# Altium schematic sheet Power.SchDoc(Power)
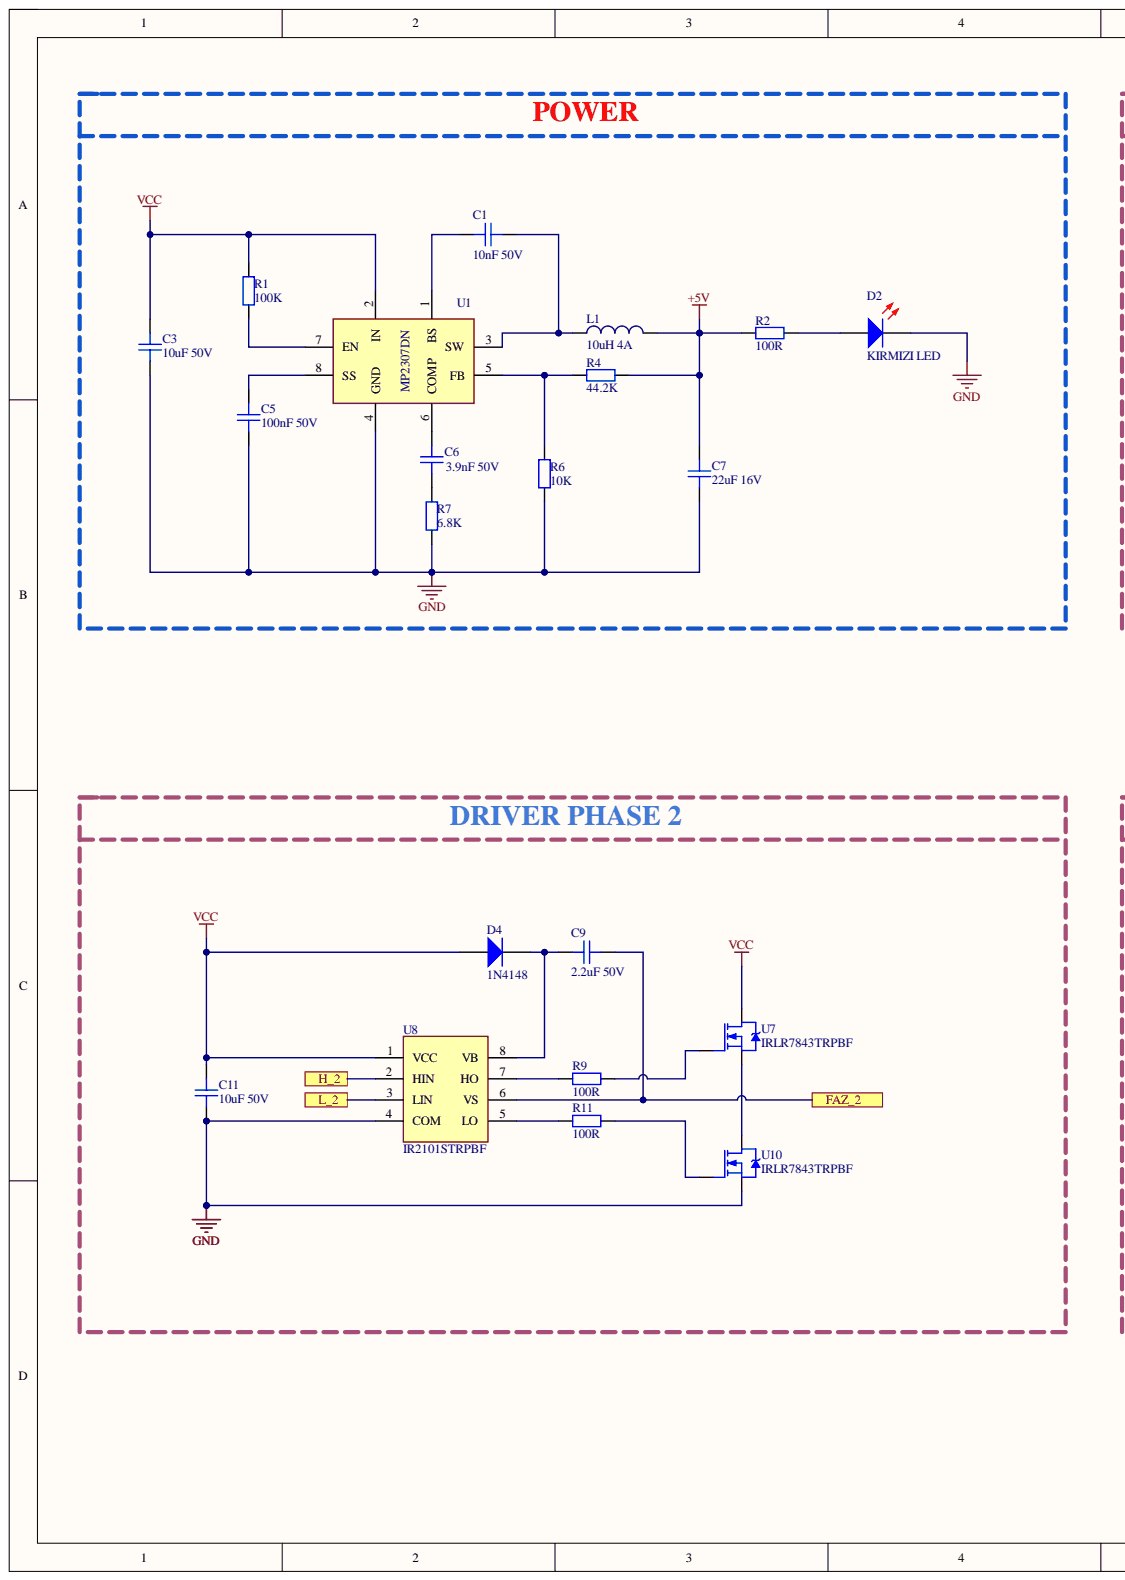
[diagram p0: Power.SchDoc, left]
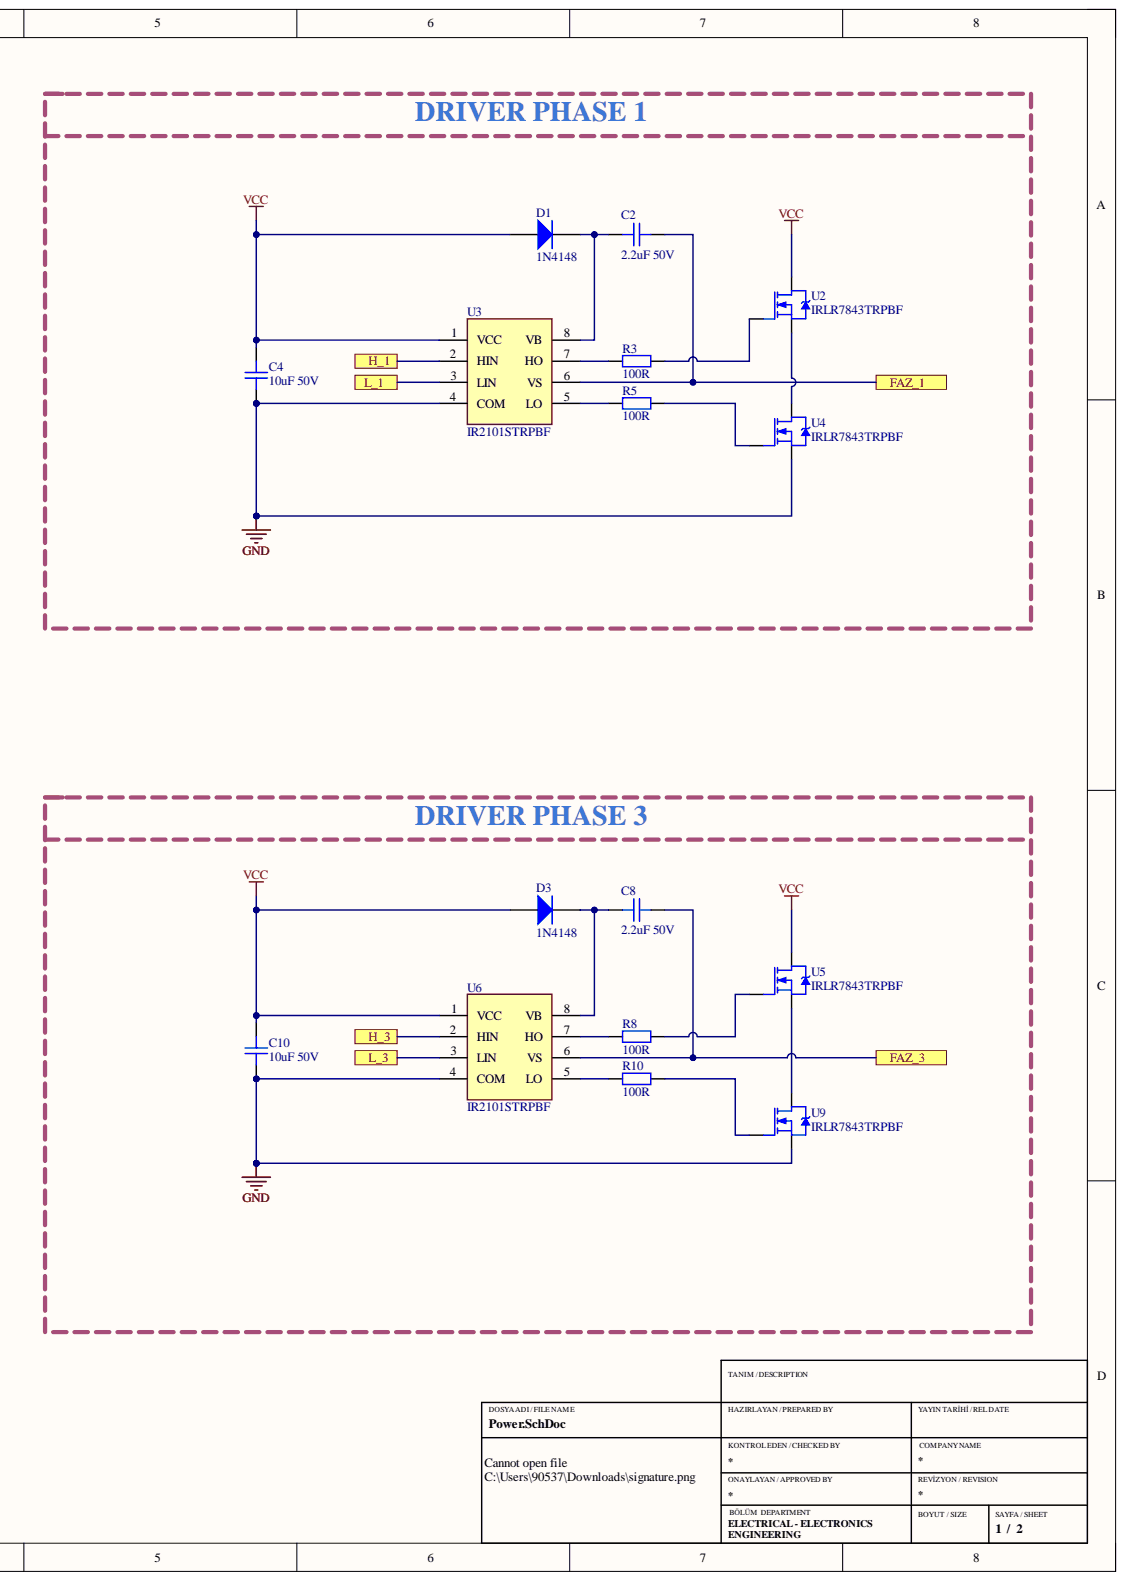
[diagram p1: Power.SchDoc, right]

## Parts
C1  Deger: 10nF 50V  [CAP 0805/2012]  (hidden comment: CAP CER 10000PF 50V X7R 0805)
C2  Deger: 2.2uF 50V  [CAP 1206/3216]  (hidden comment: CAP CER 2.2UF 50V X5R 1206)
C3  Deger: 10uF 50V  [CAP 1206/3216]  (hidden comment: CAP CER 10UF 50V X5R 1206)
C4  Deger: 10uF 50V  [CAP 1206/3216]  (hidden comment: CAP CER 10UF 50V X5R 1206)
C5  CAP CER 0.1UF 50V X7R 0805  [CAP 0805/2012]  (value not drawn)
C6  CAP CER 3900PF 50V X7R 0805  [CAP 0805/2012]  (value not drawn)
C7  Deger: 22uF 16V  [CAP 1206/3216]  (hidden comment: CAP CERAMIC 22UF 16V X7S 20% PAD)
C8  Deger: 2.2uF 50V  [CAP 1206/3216]  (hidden comment: CAP CER 2.2UF 50V X5R 1206)
C9  Deger: 2.2uF 50V  [CAP 1206/3216]  (hidden comment: CAP CER 2.2UF 50V X5R 1206)
C10  Deger: 10uF 50V  [CAP 1206/3216]  (hidden comment: CAP CER 10UF 50V X5R 1206)
C11  Deger: 10uF 50V  [CAP 1206/3216]  (hidden comment: CAP CER 10UF 50V X5R 1206)
D1  1N4148 Diyot  [SOD-123]  (value not drawn)
D2  Cins: LED  Deger: KIRMIZI LED  [SMD: LED 0805/2012 RED]  (hidden comment: Red 645nm LED Indication - Discrete 1.8V 0805)
D3  1N4148 Diyot  [SOD-123]  (value not drawn)
D4  1N4148 Diyot  [SOD-123]  (value not drawn)
L1  FIXED IND 10UH 4A 68 MOHM SMD  [ASPI-0630LR-100M-T15]  (value not drawn)
R1  Deger: 100K  [RES_0805]  (hidden comment: RES SMD 100K OHM 1% 1/8W 0805)
R2  Deger: 100R  [RES_0805]  (hidden comment: RES SMD 100 OHM 1% 1/8W 0805)
R3  Deger: 100R  [RES_0805]  (hidden comment: RES SMD 100 OHM 1% 1/8W 0805)
R4  Deger: 44.2K  [RES_0805]  (hidden comment: RES SMD 44.2K OHM 1% 1/8W 0805)
R5  Deger: 100R  [RES_0805]  (hidden comment: RES SMD 100 OHM 1% 1/8W 0805)
R6  Deger: 10K  [RES_0805]  (hidden comment: RES SMD 10K OHM 1% 1/8W 0805)
R7  Deger: 6.8K  [RES_0805]  (hidden comment: RES SMD 6.8K OHM 1% 1/8W 0805)
R8  Deger: 100R  [RES_0805]  (hidden comment: RES SMD 100 OHM 1% 1/8W 0805)
R9  Deger: 100R  [RES_0805]  (hidden comment: RES SMD 100 OHM 1% 1/8W 0805)
R10  Deger: 100R  [RES_0805]  (hidden comment: RES SMD 100 OHM 1% 1/8W 0805)
R11  Deger: 100R  [RES_0805]  (hidden comment: RES SMD 100 OHM 1% 1/8W 0805)
U1  IC REG BUCK ADJUSTABLE 3A 8SOIC  [SOIC8_PAD]  (value not drawn)
U2  Deger: IRLR7843TRPBF  [DPAK]  (hidden comment: MOSFET N-CH 30V 161A DPAK)
U3  Deger: IR2101STRPBF  [SOIC8]  (hidden comment: IC GATE DRVR HALF-BRIDGE 8SOIC)
U4  Deger: IRLR7843TRPBF  [DPAK]  (hidden comment: MOSFET N-CH 30V 161A DPAK)
U5  Deger: IRLR7843TRPBF  [DPAK]  (hidden comment: MOSFET N-CH 30V 161A DPAK)
U6  Deger: IR2101STRPBF  [SOIC8]  (hidden comment: IC GATE DRVR HALF-BRIDGE 8SOIC)
U7  Deger: IRLR7843TRPBF  [DPAK]  (hidden comment: MOSFET N-CH 30V 161A DPAK)
U8  Deger: IR2101STRPBF  [SOIC8]  (hidden comment: IC GATE DRVR HALF-BRIDGE 8SOIC)
U9  Deger: IRLR7843TRPBF  [DPAK]  (hidden comment: MOSFET N-CH 30V 161A DPAK)
U10  Deger: IRLR7843TRPBF  [DPAK]  (hidden comment: MOSFET N-CH 30V 161A DPAK)

## Ports
FAZ_1, FAZ_2, FAZ_3, H_1, H_2, H_3, L_1, L_2, L_3

## Nets
+5V: C7.2 L1.2 R2.1 R4.2
GND: C3.1 C4.1 C5.1 C7.1 C10.1 C11.1 D2.2 R6.1 R7.1 U1.4(GND) U3.4(COM) U4.3 U6.4(COM) U8.4(COM) U9.3 U10.3
VCC: C3.2 C4.2 C10.2 C11.2 D1.1 D3.1 D4.1 R1.2 U1.2(IN) U2.4 U3.1(VCC) U5.4 U6.1(VCC) U7.4 U8.1(VCC)
FAZ_1: C2.2 U3.6(VS) ; port FAZ_1
FAZ_2: C9.2 U8.6(VS) ; port FAZ_2
FAZ_3: C8.2 U6.6(VS) ; port FAZ_3
H_1: U3.2(HIN) ; port H_1
H_2: U8.2(HIN) ; port H_2
H_3: U6.2(HIN) ; port H_3
L_1: U3.3(LIN) ; port L_1
L_2: U8.3(LIN) ; port L_2
L_3: U6.3(LIN) ; port L_3
NetC1_1: C1.1 U1.1(BS)
NetC1_2: C1.2 L1.1 U1.3(SW)
NetC2_1: C2.1 D1.2 U3.8(VB)
NetC5_2: C5.2 U1.8(SS)
NetC6_1: C6.1 R7.2
NetC6_2: C6.2 U1.6(COMP)
NetC8_1: C8.1 D3.2 U6.8(VB)
NetC9_1: C9.1 D4.2 U8.8(VB)
NetD2_1: D2.1 R2.2
NetR1_1: R1.1 U1.7(EN)
NetR3_1: R3.1 U3.7(HO)
NetR3_2: R3.2 U2.1
NetR4_1: R4.1 R6.2 U1.5(FB)
NetR5_1: R5.1 U3.5(LO)
NetR5_2: R5.2 U4.1
NetR8_1: R8.1 U6.7(HO)
NetR8_2: R8.2 U5.1
NetR9_1: R9.1 U8.7(HO)
NetR9_2: R9.2 U7.1
NetR10_1: R10.1 U6.5(LO)
NetR10_2: R10.2 U9.1
NetR11_1: R11.1 U8.5(LO)
NetR11_2: R11.2 U10.1
NetU2_3: U2.3 U4.4
NetU5_3: U5.3 U9.4
NetU7_3: U7.3 U10.4

## Library data of the parts
C1 = 10nF 50V 0805: 10000pF ±10% 50V Ceramic Capacitor X7R 0805 (2012 Metric); +Sicaklik: +125; -Sicaklik: -55; Cins: Kondansator; Kılıf: 0805; Lehim Yöntemi: SMD; Uretici Kodu: CL21B103KBANNNC; Component Kind: Standard; MSL: 1 (Unlimited); Library Name: PROJE.SchLib
C2 C8 C9 = 2.2uF 50V 1206: 2.2µF ±10% 50V Ceramic Capacitor X5R 1206 (3216 Metric); +Sicaklik: +85; -Sicaklik: -55; Cins: Kondansator; Kılıf: 1206; Lehim Yöntemi: SMD; Uretici Kodu: CL31A225KBHNNNE; Component Kind: Standard; MSL: 1 (Unlimited); Library Name: PROJE.SchLib
C3 C4 C10 C11 = 10uF 50V 1206: 10µF ±10% 50V Ceramic Capacitor X5R 1206 (3216 Metric); +Sicaklik: +125; -Sicaklik: -55; Cins: Kondansator; Kılıf: 1206; Lehim Yöntemi: SMD; Uretici Kodu: CL31A106KBHNNNE; Component Kind: Standard; MSL: 1 (Unlimited); Library Name: PROJE.SchLib
C5 = 100nF 50V 0805: 0.1µF ±10% 50V Ceramic Capacitor X7R 0805 (2012 Metric); +Sicaklik: +125; -Sicaklik: -55; Cins: Kondansator; Kılıf: 0805; Lehim Yöntemi: SMD; Uretici Kodu: CL21B104KBCNNNC; Component Kind: Standard; Deger: 100nF 50V; MSL: 1 (Unlimited); Library Name: PROJE.SchLib
C6 = 3.9nF 50V 0805: 3900pF ±10% 50V Ceramic Capacitor X7R 0805 (2012 Metric); +Sicaklik: +125; -Sicaklik: -55; Cins: Kondansator; Kılıf: 0805; Lehim Yöntemi: SMD; Uretici Kodu: CL21B392KBANNNC; Component Kind: Standard; Deger: 3.9nF 50V; MSL: 1 (Unlimited); Library Name: PROJE.SchLib
C7 = 22uF 16V 1206: 22µF ±20% 16V Ceramic Capacitor X7S 1206 (3216 Metric); +Sicaklik: +125; -Sicaklik: -55; Cins: Kondansator; Kılıf: 1206; Lehim Yöntemi: SMD; Uretici Kodu: GRM31CC71C226ME11L; Component Kind: Standard; MSL: 1 (Unlimited); Library Name: PROJE.SchLib
D1 D3 D4 = 1N4148: DIODE GEN PURP 100V 150MA SOD123; +Sicaklik: +150; -Sicaklik: -65; Lehim Yöntemi: SMD; Uretici Kodu: 1N4148W-TP; Cins: Diyot; Component Kind: Standard; Deger: 1N4148; Kılıf: SOD123; MSL: 1 (Unlimited); Library Name: PROJE.SchLib
D2 = LED_Kırmızı: LED RED DIFFUSED 0805 SMD; +Sicaklik: +125; -Sicaklik: -40; Kılıf: 0805; Uretici Kodu: LH R974-LP-1; Component Kind: Standard; Lehim Yöntemi: SMD; MSL: 1 (Unlimited); Library Name: PROJE.SchLib
L1 = 10uH 4A: 10µH Shielded Molded Inductor 4A 68mOhm Max Nonstandard; +Sicaklik: +125; -Sicaklik: -55; Cins: Bobin; Component Kind: Standard; Deger: 10uH 4A; Kılıf: Nonstandard; Lehim Yöntemi: SMD; MSL: 1 (Unlimited); Uretici Kodu: ASPI-0630LR-100M-T15; Library Name: PROJE.SchLib
R1 = 100K 0805: 100 kOhms ±1% 0.125W, 1/8W Chip Resistor 0805 (2012 Metric) Moisture Resistant Thick Film; +Sicaklik: +155; -Sicaklik: -55; Cins: Direnc; Kılıf: 0805; Uretici Kodu: RC0805FR-07100KL; Component Kind: Standard; Lehim Yöntemi: SMD; MSL: 1 (Unlimited); Library Name: PROJE.SchLib
R2 R3 R5 R8-R11 = 100R 0805: 100 Ohms ±1% 0.125W, 1/8W Chip Resistor 0805 (2012 Metric) Moisture Resistant Thick Film; +Sicaklik: +155; -Sicaklik: -55; Cins: Direnc; Kılıf: 0805; Uretici Kodu: RC0805FR-07100RL; Component Kind: Standard; Lehim Yöntemi: SMD; MSL: 1 (Unlimited); Library Name: PROJE.SchLib
R4 = 44.2K 0805: 44.2 kOhms ±1% 0.125W, 1/8W Chip Resistor 0805 (2012 Metric) Moisture Resistant Thick Film; +Sicaklik: +155; -Sicaklik: -55; Cins: Direnc; Kılıf: 0805; Uretici Kodu: RC0805FR-0744K2L; Component Kind: Standard; Lehim Yöntemi: SMD; MSL: 1 (Unlimited); Library Name: PROJE.SchLib
R6 = 10K 0805: 10 kOhms ±1% 0.125W, 1/8W Chip Resistor 0805 (2012 Metric) Moisture Resistant Thick Film; +Sicaklik: +155; -Sicaklik: -55; Cins: Direnc; Kılıf: 0805; Uretici Kodu: RC0805FR-0710KL; Component Kind: Standard; Lehim Yöntemi: SMD; MSL: 1 (Unlimited); Library Name: PROJE.SchLib
R7 = 6.8K 0805: 6.8 kOhms ±1% 0.125W, 1/8W Chip Resistor 0805 (2012 Metric) Moisture Resistant Thick Film; +Sicaklik: +155; -Sicaklik: -55; Cins: Direnc; Kılıf: 0805; Uretici Kodu: RC0805FR-076K8L; Component Kind: Standard; Lehim Yöntemi: SMD; MSL: 1 (Unlimited); Library Name: PROJE.SchLib
U1 = MP2307DN: Buck Switching Regulator IC Positive Adjustable 0.925V 1 Output 3A 8-SOIC (0.154", 3.90mm Width) Exposed Pad; +Sicaklik: +85; -Sicaklik: -40; Lehim Yöntemi: SMD; Uretici Kodu: MP2307DN-LF-Z; Cins: IC; Component Kind: Standard; Deger: MP2307DN; Kılıf: 8-SOIC; MSL: 2A (4 Weeks); Library Name: PROJE.SchLib
U2 U4 U5 U7 U9 U10 = IRLR7843: N-Channel 30V 161A (Tc) 140W (Tc) Surface Mount D-Pak; +Sicaklik: +175; -Sicaklik: -55; Kılıf: DPAK(TO-252-3); Lehim Yöntemi: SMD; Cins: MOSFET; Component Kind: Standard; MSL: 1 (Unlimited); Uretici Kodu: IRLR7843TRPBF; Library Name: PROJE.SchLib
U3 U6 U8 = IR2101: Half-Bridge Gate Driver IC Non-Inverting 8-SOIC; +Sicaklik: +150; -Sicaklik: -40; Lehim Yöntemi: SMD; Cins: IC; Component Kind: Standard; Kılıf: 8-SOIC; MSL: 2A (4 Weeks); Uretici Kodu: IR2101STRPBF; Library Name: PROJE.SchLib
